# Altium schematic sheet 419-005-01-02_PG 16 - BOARD_POWER 5V.SchDoc("419-005-01-02_PG 16 - BOARD_POWER 5V")
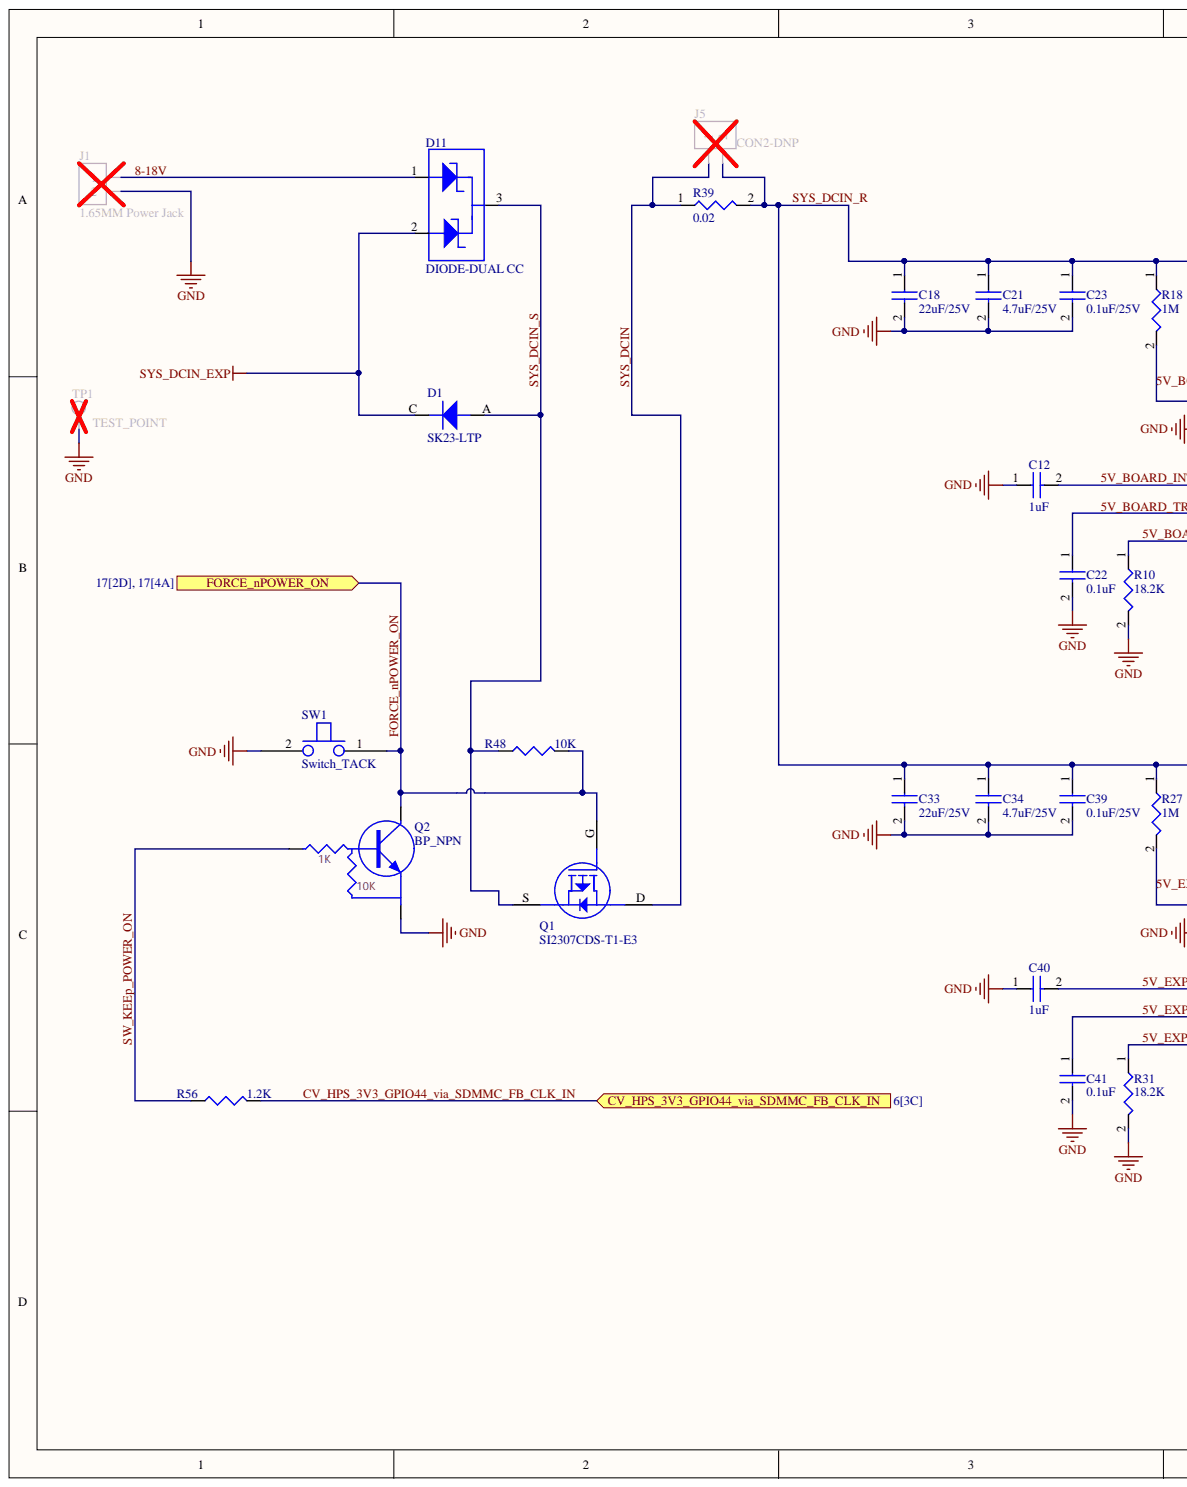
[diagram p0: 419-005-01-02_PG 16 - BOARD_POWER 5V.SchDoc, left]
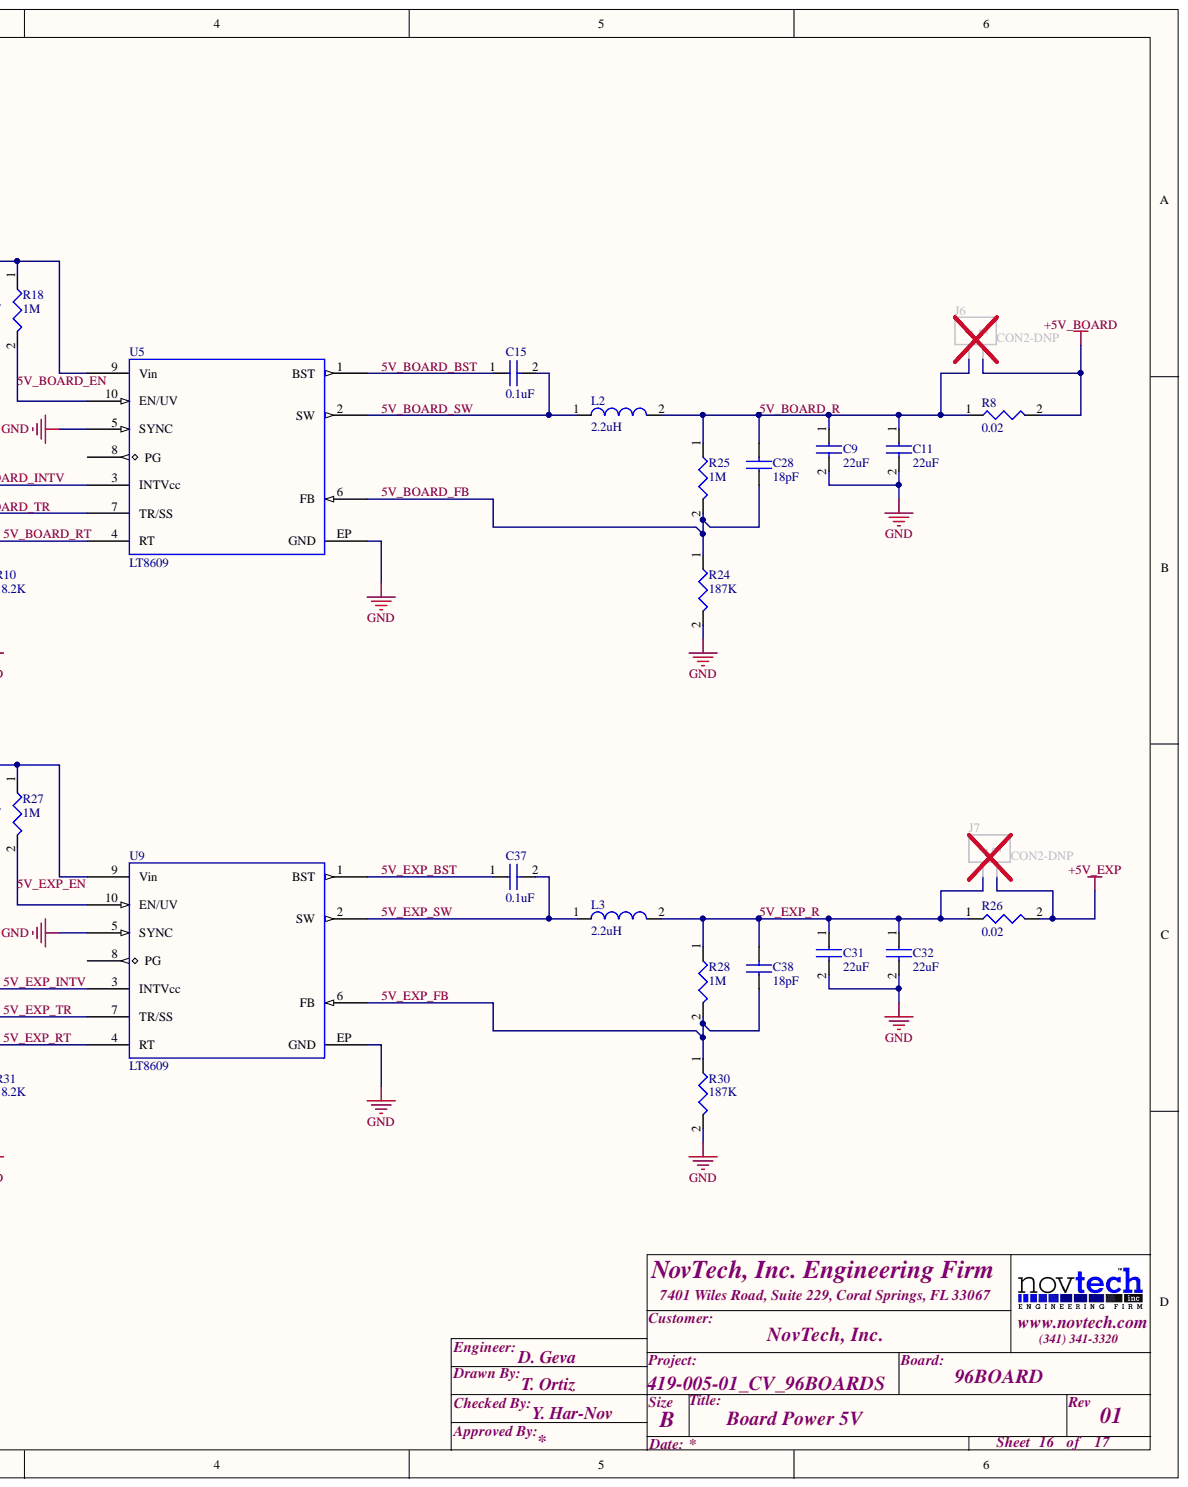
[diagram p1: 419-005-01-02_PG 16 - BOARD_POWER 5V.SchDoc, right]

## Parts
C9  22uF  [0805_C]
C11  22uF  [0805_C]
C12  1uF  [0201_C]
C15  0.1uF  [0201_C]
C18  22uF/25V  [0805_C]
C21  4.7uF/25V  [0603_C]
C22  0.1uF  [0201_C]
C23  0.1uF/25V  [0201_C]
C28  18pF  [0201_C]
C31  22uF  [0805_C]
C32  22uF  [0805_C]
C33  22uF/25V  [0805_C]
C34  4.7uF/25V  [0603_C]
C37  0.1uF  [0201_C]
C38  18pF  [0201_C]
C39  0.1uF/25V  [0201_C]
C40  1uF  [0201_C]
C41  0.1uF  [0201_C]
D1  SK23-LTP  [SMB]
D11  DIODE-DUAL CC
J1  1.65MM Power Jack  [PJ-041H]
J5  CON2-DNP  [BH2_2MM_1_1.4]
J6  CON2-DNP  [BH2_2MM_1_1.4]
J7  CON2-DNP  [BH2_2MM_1_1.4]
L2  2.2uH  [IND_4X4X2.1_XFL4020]
L3  2.2uH  [IND_4X4X2.1_XFL4020]
Q1  SI2307CDS-T1-E3  [SOT23-MOSFET]
Q2  BP_NPN  [SOT23-NPN-PNP]
R8  0.02  [1206_R]
R10  18.2K  [0402_R]
R18  1M  [0402_R]
R24  187K  [0402_R]
R25  1M  [0402_R]
R26  0.02  [1206_R]
R27  1M  [0402_R]
R28  1M  [0402_R]
R30  187K  [0402_R]
R31  18.2K  [0402_R]
R39  0.02  [1206_R]
R48  10K  [0201_R]
R56  1.2K  [0201_R]
SW1  Switch_TACK  [KMR2]
TP1  TEST_POINT  [TP_40_60]
U5  LT8609  [MSOP10 - MSE]
U9  LT8609  [MSOP10 - MSE]

## Ports
CV_HPS_3V3_GPIO44_VIA_SDMMC_FB_CLK_IN, FORCE_NPOWER_ON

## Nets
+5V_BOARD: J6.2 R8.2
+5V_EXP: J7.2 R26.2
GND: C9.2 C11.2 C12.1 C18.2 C21.2 C22.2 C23.2 C31.2 C32.2 C33.2 C34.2 C39.2 C40.1 C41.2 J1.2 Q2.EMIT R10.2 R24.2 R30.2 R31.2 SW1.2 TP1.1 U5.5(SYNC) U5.EP(GND) U9.5(SYNC) U9.EP(GND)
SYS_DCIN_EXP: D1.C D11.2
5V_BOARD_BST: C15.1 U5.1(BST)
5V_BOARD_EN: R18.2 U5.10(EN/UV)
5V_BOARD_FB: C28.1 R24.1 R25.2 U5.6(FB)
5V_BOARD_INTV: C12.2 U5.3(INTVcc)
5V_BOARD_R: C9.1 C11.1 C28.2 J6.1 L2.2 R8.1 R25.1
5V_BOARD_RT: R10.1 U5.4(RT)
5V_BOARD_SW: C15.2 L2.1 U5.2(SW)
5V_BOARD_TR: C22.1 U5.7(TR/SS)
5V_EXP_BST: C37.1 U9.1(BST)
5V_EXP_EN: R27.2 U9.10(EN/UV)
5V_EXP_FB: C38.1 R28.2 R30.1 U9.6(FB)
5V_EXP_INTV: C40.2 U9.3(INTVcc)
5V_EXP_R: C31.1 C32.1 C38.2 J7.1 L3.2 R26.1 R28.1
5V_EXP_RT: R31.1 U9.4(RT)
5V_EXP_SW: C37.2 L3.1 U9.2(SW)
5V_EXP_TR: C41.1 U9.7(TR/SS)
8-18V: D11.1 J1.1
CV_HPS_3V3_GPIO44_VIA_SDMMC_FB_CLK_IN: R56.2 ; port CV_HPS_3V3_GPIO44_VIA_SDMMC_FB_CLK_IN
FORCE_NPOWER_ON: Q1.G Q2.COLL R48.2 SW1.1 ; port FORCE_NPOWER_ON
SW_KEEP_POWER_ON: Q2.BASE R56.1
SYS_DCIN: J5.1 Q1.D R39.1
SYS_DCIN_R: C18.1 C21.1 C23.1 C33.1 C34.1 C39.1 J5.2 R18.1 R27.1 R39.2 U5.9(Vin) U9.9(Vin)
SYS_DCIN_S: D1.A D11.3 Q1.S R48.1

Not connected: U5 8(PG); U9 8(PG)

## Library data of the parts
C9 C11 C18 C31-C33 = CAP_0805: Capasitor 0805 footprint; Bottom: 10; Right: 20; Component Kind: Standard; Library Name: PASSIVES.SchLib
C12 C15 C22 C23 C28 C37-C41 = CAP_0201: Capasitor 0201 footprint; Component Kind: Standard; Library Name: PASSIVES.SchLib
C21 C34 = CAP_0603: Capasitor 0603 footprint; Bottom: 10; Right: 20; Component Kind: Standard; Library Name: PASSIVES.SchLib
D1 = DIODE: Marking: S3; MFG: MicroCommerical; TYPE: SMT; Component Kind: Standard; DigiKey: 1505-SK23-LTP-CHP; Library Name: PASSIVES.SchLib; PART: SK23-LTP; RoHS: Yes
D11 = DIODE-DUAL CC: PART: PDS1240CTL-13; MFG: Didoe Inc; TEMP: -65°C to +150°C; TYPE: SMT; Component Kind: Standard; DigiKey: PDS1240CTL-13DICT-ND; Library Name: PASSIVES.SchLib; RoHS: Yes
J1 = CON2: MFG: CUI Inc; Component Kind: Standard; Library Name: CONNECTORS_MECHANICAL.SchLib; RoHS: Yes
J5-J7 = CON2: PART: NRPN021PAEN-RC; MFG: Sullins; Component Kind: Standard; DigiKey: S5800-02-ND; Library Name: CONNECTORS_MECHANICAL.SchLib; RoHS: Yes; TYPE: TH
L2 L3 = INDUCTOR: PART: XFL4020-222MEB; MFG: Coilcraft; TEMP: -40°C to +125°C; Type: MST; Component Kind: Standard; Library Name: PASSIVES.SchLib; RoHS: Yes
Q1 = P-MOSFET: P-CHANNEL; MARKING: N7; MFG: Vishay; TYPE: SMT; Component Kind: Standard; DigiKey: SI2307CDS-T1-E3CT-ND; Library Name: PASSIVES.SchLib; PART: SI2307CDS-T1-E3; RoHS: Yes
Q2 = NPN_PreBIAS: PART: PDTD113ZK; MARKING: *7V; MFG: NXP; TEMP: -65°C to +150C°; TYPE: SMT; Component Kind: Standard; DigiKey: PDTD113ZK,115-ND; Library Name: PASSIVES.SchLib; RoHS: Yes
R8 R26 R39 = RESISOTR 1206: Resistor 1206 footprint; PART: CSR1206FK20L0; MFG: Stackpole; TEMP: -55°C to +155°C; Component Kind: Standard; Digikey: CSR1206FK20L0TR-ND; Library Name: PASSIVES.SchLib; RoHS: Yes; TYPE: SMT
R10 R18 R24 R25 R27 R28 R30 R31 = RESISOTR 0402: Resistor 0402 footprint; Component Kind: Standard; Library Name: PASSIVES.SchLib
R48 = RESISOTR 0201: Resistor 0201 footprint; PART: ERJ-1GEF1002C; MFG: Panasonic; Component Kind: Standard; Digikey: P10.0KABCT-ND; Library Name: PASSIVES.SchLib; RoHS: Yes; TYPE: SMT
R56 = RESISOTR 0201: Resistor 0201 footprint; PART: ERJ-1GEF1201C; MFG: Panasonic; Component Kind: Standard; Digikey: P1.20KABCT-ND; Library Name: PASSIVES.SchLib; RoHS: Yes; TYPE: SMT
SW1 = Switch_TACK: Switch, 2 contacts; PART: KMR241NG ULC LFS; MFG: C&K; TEMP: -40°C to +85°C; Component Kind: Standard; DigiKey: CKN10285CT-ND; Library Name: CONNECTORS_MECHANICAL.SchLib; RoHS: Yes; TYPE: SMT
TP1 = TEST_POINT: PCB Test Point; Component Kind: Standard; Library Name: PASSIVES.SchLib
U5 U9 = LT8609: 42V, 2A/3A Peak  Synchronous Step-Down Regulator; PART: LT8609EMSE#TRPBF; Marking: LTGRW; MFG: Linear; TEMP: -40°C to +125°; Component Kind: Standard; DigiKey: LT8609EMSE#PBF-ND; Library Name: IC_SchLib.SCHLIB; RoHS: Yes; TYPE: SMT
